# Altium schematic sheet ESP32S3_2511.SchDoc
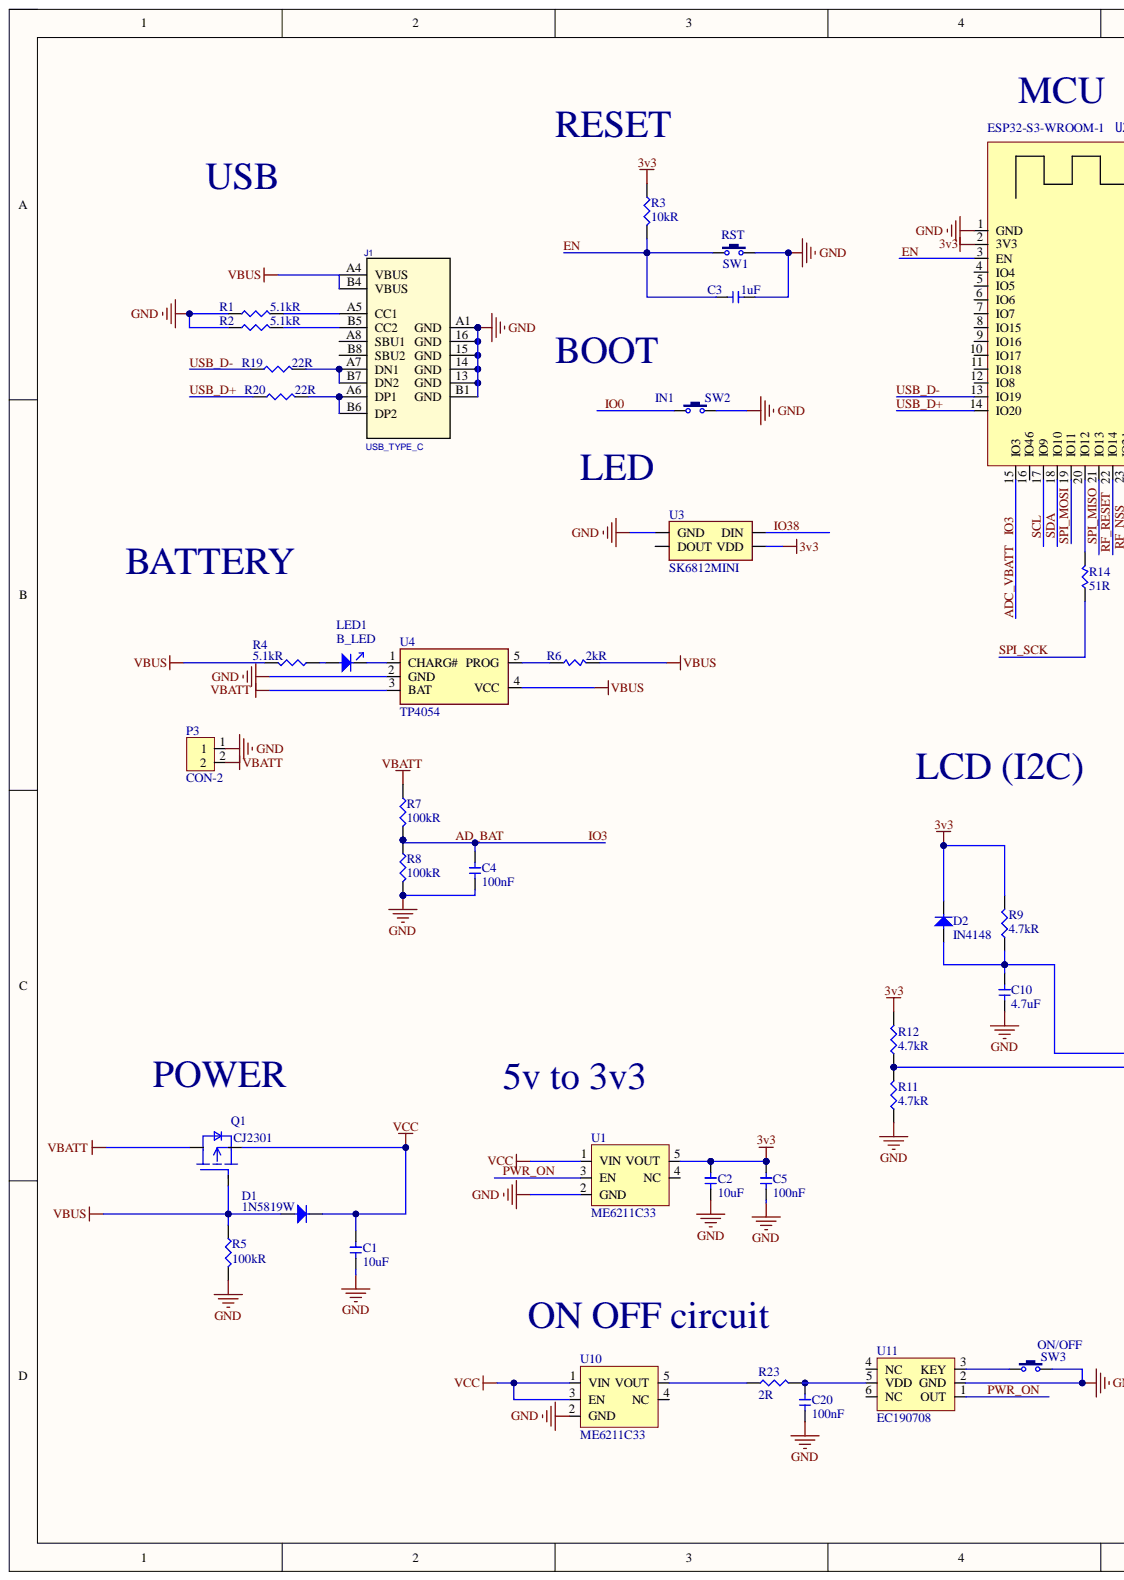
[diagram p0: ESP32S3_2511.SchDoc, left]
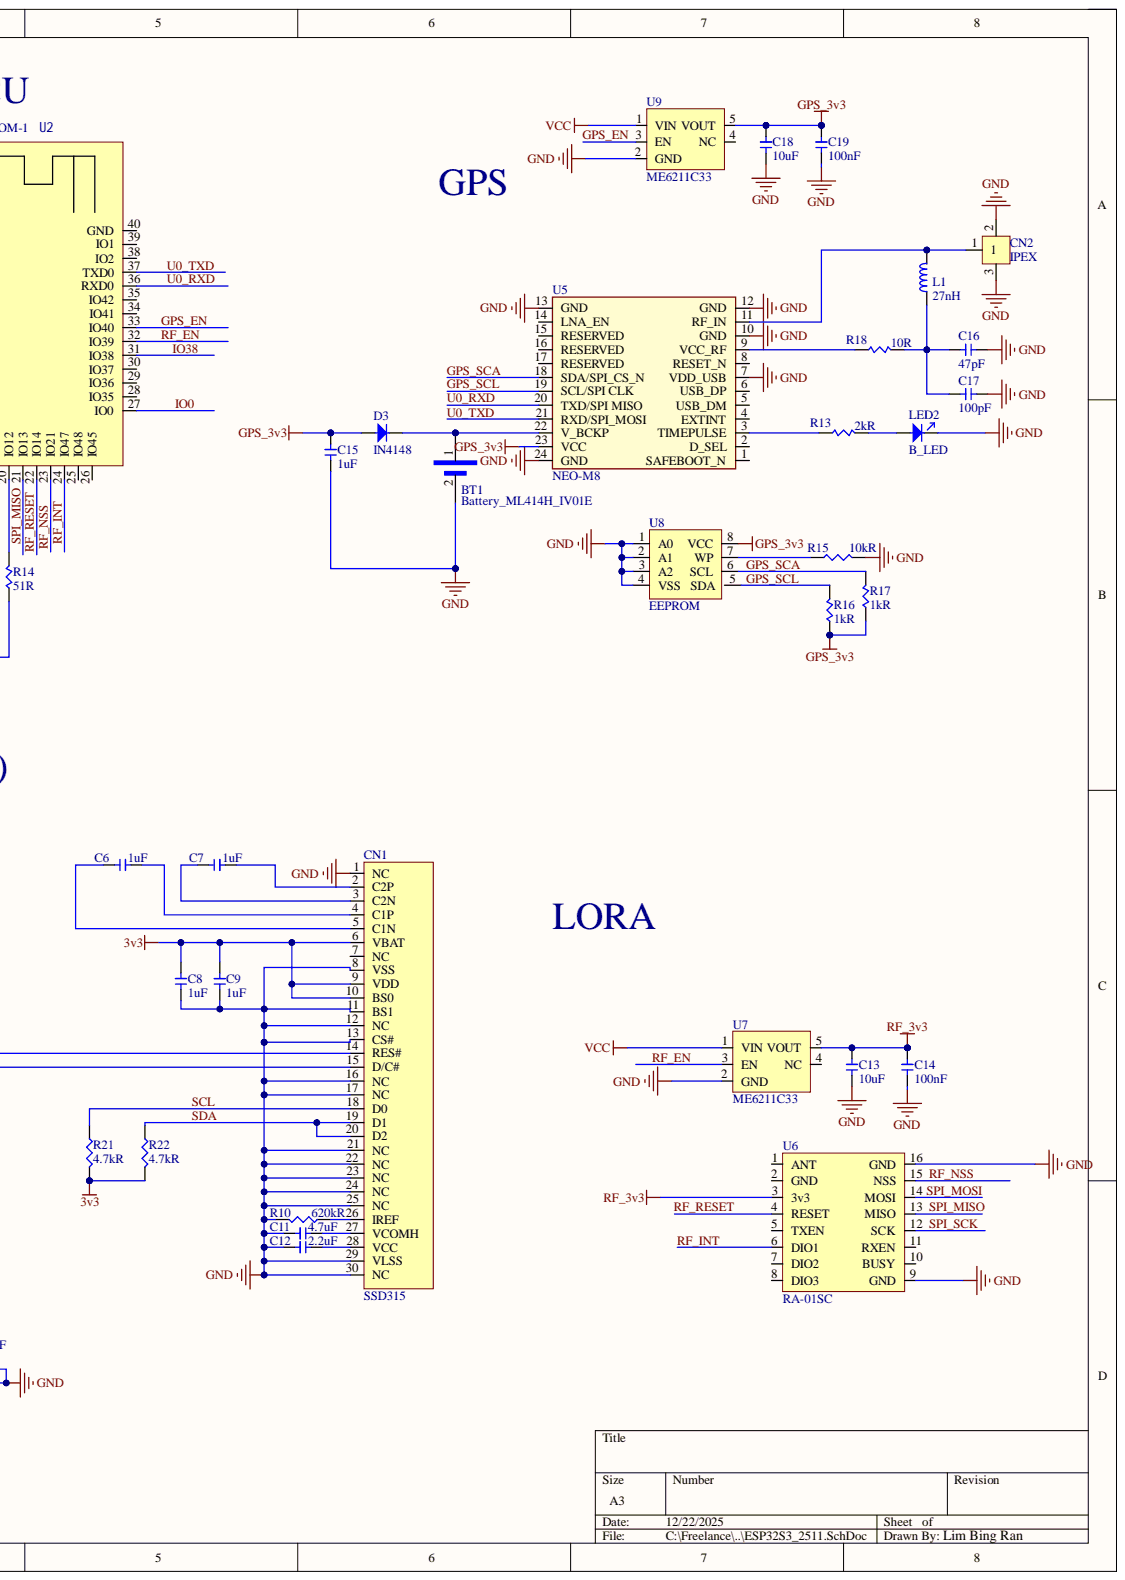
[diagram p1: ESP32S3_2511.SchDoc, right]

## Parts
BT1  Battery_ML414H_IV01E  [SEIKO_ML414H_IV01E]
C1  10uF  [0603C]
C2  10uF  [0603C]
C3  1uF  [0603C]
C4  100nF  [0603C]
C5  100nF  [0603C]
C6  1uF  [0603C]
C7  1uF  [0603C]
C8  1uF  [0603C]
C9  1uF  [0603C]
C10  4.7uF  [0603C]
C11  4.7uF  [0603C]
C12  2.2uF  [0603C]
C13  10uF  [0603C]
C14  100nF  [0603C]
C15  1uF  [0603C]
C16  47pF  [0603C]
C17  100pF  [0603C]
C18  10uF  [0603C]
C19  100nF  [0603C]
C20  100nF  [0603C]
CN1  SSD315  [FPC 30P 0.5mm TOP CNT SMD]
CN2  IPEX  [IPEX_20279-001E-03]
D1  1N5819W  [SOD-123]
D2  IN4148  [SOD-123]
D3  IN4148  [SOD-123]
J1  USB_TYPE_C  [USB16-typeC_8.94x6.2mm]
L1  27nH  [0603L]
LED1  B_LED  [led0603 - blue]
LED2  B_LED  [led0603 - blue]
P3  CON-2  [JST_S2B-PH-K-S(LF)(SN)]
Q1  CJ2301  [sot23-3]
R1  5.1kR  [0603]
R2  5.1kR  [0603]
R3  10kR  [0603]
R4  5.1kR  [0603]
R5  100kR  [0603]
R6  2kR  [0603]
R7  100kR  [0603]
R8  100kR  [0603]
R9  4.7kR  [0603]
R10  620kR  [0603]
R11  4.7kR  [0603]
R12  4.7kR  [0603]
R13  2kR  [0603]
R14  51R  [0603]
R15  10kR  [0603]
R16  1kR  [0603]
R17  1kR  [0603]
R18  10R  [0603]
R19  22R  [0603]
R20  22R  [0603]
R21  4.7kR  [0603]
R22  4.7kR  [0603]
R23  2R  [0603]
SW1  RST  [KEY 3.2*4.2*2.5 4P SMD]
SW2  IN1  [KEY 3.2*4.2*2.5 4P SMD]
SW3  ON/OFF  [TACSW SMD 6MMX6MM - 3D]
U1  ME6211C33  [SOT-23-5]
U2  ESP32-S3-WROOM-1  [ESP32-S3-WROOM]
U3  SK6812MINI  [SK6812MINI]
U4  TP4054  [SOT-23-5]
U5  NEO-M8  [NEO]
U6  RA-01SC  [Ra-01SC-P]
U7  ME6211C33  [SOT-23-5]
U8  EEPROM  [SOIC-8]
U9  ME6211C33  [SOT-23-5]
U10  ME6211C33  [SOT-23-5]
U11  EC190708  [SOT-23-6]

## Nets
3v3: C2.1 C5.1 C8.1 C9.1 CN1.6(VBAT) CN1.9(VDD) CN1.10(BS0) D2.K R3.1 R9.2 R12.2 R21.2 R22.2 U1.5(VOUT) U2.2(3V3) U3.VDD
GND: BT1.2 C1.2 C2.2 C3.1 C4.2 C5.2 C8.2 C9.2 C10.2 C11.1 C12.1 C13.2 C14.2 C15.2 C16.2 C17.2 C18.2 C19.2 C20.2 CN1.1(NC) CN1.8(VSS) CN1.11(BS1) CN1.12(NC) CN1.13(CS#) CN1.16(NC) CN1.17(NC) CN1.21(NC) CN1.22(NC) CN1.23(NC) CN1.24(NC) CN1.25(NC) CN1.29(VLSS) CN1.30(NC) CN2.2 CN2.3 J1.13(GND) J1.14(GND) J1.15(GND) J1.16(GND) J1.A1(GND) J1.B1(GND) LED2.2 P3.1 R1.1 R2.1 R5.2 R8.2 R10.2 R11.1 R15.2 SW1.2 SW2.2 SW3.2 U1.2(GND) U2.1(GND) U3.VSS(GND) U4.2(GND) U5.7(VDD_USB) U5.10(GND) U5.12(GND) U5.13(GND) U5.24(GND) U6.9(GND) U6.16(GND) U7.2(GND) U8.1(A0) U8.2(A1) U8.3(A2) U8.4(VSS) U9.2(GND) U10.2(GND) U11.2(GND)
GPS_3v3: C15.1 C18.1 C19.1 D3.A R16.1 R17.1 U5.23(VCC) U8.8(VCC) U9.5(VOUT)
RF_3v3: C13.1 C14.1 U6.3(3v3) U7.5(VOUT)
VBATT: P3.2 Q1.3 R7.1 U4.3(BAT)
VBUS: D1.A J1.A4(VBUS) J1.B4(VBUS) Q1.1 R4.2 R5.1 R6.2 U4.4(VCC)
VCC: C1.1 D1.K Q1.2 U1.1(VIN) U7.1(VIN) U9.1(VIN) U10.1(VIN) U10.3(EN)
AD_BAT: C4.1 R7.2 R8.1 U2.15(IO3)
EN: C3.2 R3.2 SW1.1 U2.3(EN)
GPS_EN: U2.33(IO40) U9.3(EN)
GPS_SCA: R17.2 U5.18(SDA/SPI_CS_N) U8.6(SCL)
GPS_SCL: R16.2 U5.19(SCL/SPI_CLK) U8.5(SDA)
IO0: SW2.1 U2.27(IO0)
IO38: U2.31(IO38) U3.DIN
PWR_ON: U1.3(EN) U11.1(OUT)
RF_EN: U2.32(IO39) U7.3(EN)
RF_INT: U2.24(IO47) U6.6(DIO1)
RF_NSS: U2.23(IO21) U6.15(NSS)
RF_RESET: U2.22(IO14) U6.4(RESET)
SCL: CN1.18(D0) R21.1 U2.17(IO9)
SDA: CN1.19(D1) CN1.20(D2) R22.1 U2.18(IO10)
SPI_MISO: U2.21(IO13) U6.13(MISO)
SPI_MOSI: U2.19(IO11) U6.14(MOSI)
SPI_SCK: R14.1 U6.12(SCK)
U0_RXD: U2.36(RXD0) U5.20(TXD/SPI_MISO)
U0_TXD: U2.37(TXD0) U5.21(RXD/SPI_MOSI)
USB_D+: R20.1 U2.14(IO20)
USB_D-: R19.1 U2.13(IO19)
NetBT1_1: BT1.1 D3.K U5.22(V_BCKP)
NetC6_1: C6.1 CN1.4(C1P)
NetC6_2: C6.2 CN1.5(C1N)
NetC7_1: C7.1 CN1.2(C2P)
NetC7_2: C7.2 CN1.3(C2N)
NetC10_1: C10.1 CN1.14(RES#) D2.A R9.1
NetC11_2: C11.2 CN1.27(VCOMH)
NetC12_2: C12.2 CN1.28(VCC)
NetC16_1: C16.1 C17.1 L1.1 R18.2
NetC20_1: C20.1 R23.2 U11.5(VDD)
NetCN1_15: CN1.15(D/C#) R11.2 R12.1
NetCN1_26: CN1.26(IREF) R10.1
NetCN2_1: CN2.1 L1.2 U5.11(RF_IN)
NetJ1_A5: J1.A5(CC1) R1.2
NetJ1_A6: J1.A6(DP1) J1.B6(DP2) R20.2
NetJ1_A7: J1.A7(DN1) J1.B7(DN2) R19.2
NetJ1_B5: J1.B5(CC2) R2.2
NetLED1_1: LED1.1 R4.1
NetLED1_2: LED1.2 U4.1(CHARG#)
NetLED2_1: LED2.1 R13.2
NetR6_1: R6.1 U4.5(PROG)
NetR13_1: R13.1 U5.3(TIMEPULSE)
NetR14_2: R14.2 U2.20(IO12)
NetR15_1: R15.1 U8.7(WP)
NetR18_1: R18.1 U5.9(VCC_RF)
NetR23_1: R23.1 U10.5(VOUT)
NetSW3_1: SW3.1 U11.3(KEY)

Not connected: CN1 7(NC); J1 A8(SBU1) B8(SBU2); U1 4(NC); U2 4(IO4) 5(IO5) 6(IO6) 7(IO7) 8(IO15) 9(IO16) 10(IO17) 11(IO18) 12(IO8) 16(IO46) 25(IO48) 26(IO45) 28(IO35) 29(IO36) 30(IO37) 34(IO41) 35(IO42) 38(IO2) 39(IO1) 40(GND); U3 DOUT; U5 1(SAFEBOOT_N) 2(D_SEL) 4(EXTINT) 5(USB_DM) 6(USB_DP) 8(RESET_N) 14(LNA_EN) 15(RESERVED) 16(RESERVED) 17(RESERVED); U6 1(ANT) 2(GND) 5(TXEN) 7(DIO2) 8(DIO3) 10(BUSY) 11(RXEN); U7 4(NC); U9 4(NC); U10 4(NC); U11 4(NC) 6(NC)

## Library data of the parts
BT1 = Battery_ML414H_IV01E: Coin, 4.8mm 3 V Lithium Battery Rechargeable (Secondary) 1mAh; Manufacturer: Seiko Instruments; PartNumber: ML414H IV01E; Component Kind: Standard; Library Name: BingRan_2019.SchLib
C1 C2 C13 C18 = CAP: 贴片电容（MLCC） 0603 10µF ±20% 10V X5R; Manufacturer: Yageo(国巨); PartNumber: CC0603MRX5R6BB106; Component Kind: Standard; Library Name: BingRan_2019.SchLib
C3 C6-C9 C15 = CAP: 贴片电容（MLCC） 0603 1µF ±10% 25V X5R; Manufacturer: FH(风华); PartNumber: 0603X105K250NT; Component Kind: Standard; Library Name: BingRan_2019.SchLib
C4 C5 C14 C19 C20 = CAP: 贴片电容（MLCC） 0603 100nF ±5% 25V X7R; Manufacturer: FH(风华); PartNumber: 0603B104J250NT; Component Kind: Standard; Library Name: BingRan_2019.SchLib
C10 C11 = CAP: 贴片电容（MLCC） 0603 4.7µF ±20% 10V X5R; Manufacturer: FH(风华); PartNumber: 0603X475M100NT; Component Kind: Standard; Library Name: BingRan_2019.SchLib
C12 = CAP: 贴片电容（MLCC） 0603 2.2µF ±20% 10V X5R; Manufacturer: FH(风华); PartNumber: 0603X225M100NT; Component Kind: Standard; Library Name: BingRan_2019.SchLib
C16 = CAP: 贴片电容（MLCC） 0603 47pF ±5% 25V C0G(NP0); Manufacturer: Yageo(国巨); PartNumber: CC0603JRNPO8BN470; Component Kind: Standard; Library Name: BingRan_2019.SchLib
C17 = CAP: 贴片电容（MLCC） 0603 100pF ±5% 50V C0G(NP0); Manufacturer: Yageo(国巨); PartNumber: CC0603JRNPO9BN101; Component Kind: Standard; Library Name: BingRan_2019.SchLib
CN1 = SSD315: SMD,P=0.5mm,Surface Mount,Right Angle; Manufacturer: SHOU HAN; PartNumber: 0.5-30P XJH2.0 043; Component Kind: Standard; Library Name: BingRan_2019.SchLib
CN2 = IPEX: 射频和同轴连接器 立贴 天线连接座 镀金 内针; Manufacturer: XUNPU; PartNumber: IPEX-001; Component Kind: Standard; Library Name: BingRan_2019.SchLib
D1 = DIODE: 直流反向耐压(Vr)：40V 平均整流电流(Io)：1A 正向压降(Vf)：900mV@3A 反向电流(Ir)：1mA@40V; Manufacturer: Hottech(合科泰); Component Kind: Standard; PartNumber: 1N5819W; Library Name: BingRan_2019.SchLib
D2 D3 = DIODE: 4A 8ns 100V Independent 1.25V@150mA 300mA SOD-123 Single Diodes RoHS; Manufacturer: JSMSEMI; PartNumber: 1N4148W; Component Kind: Standard; Library Name: BingRan_2019.SchLib
J1 = USB_TYPE_C: Manufacturer: BBJconn; PartNumber: UC.01.51-11-0017; Component Kind: Standard; Library Name: BingRan_2019.SchLib
L1 = INDUCTOR: 高频电感 27nH ±5% 540mΩ 500mA 0.80mm 1.60 x 0.80mm 0603; Manufacturer: Murata; PartNumber: LQG18HN27NJ00D; Component Kind: Standard; Library Name: BingRan_2019.SchLib
LED1 LED2 = LED: LED NATIONSTAR 0603 蓝色FC-D1608BK-470H10; Component Kind: Standard; Manufacturer: LED NATIONSTAR; PartNumber: FC-D1608BK-470H10; Library Name: BingRan_2019.SchLib
P3 = CON-2: JST_S2B-PH-K-S(LF)(SN); Manufacturer: JST; Component Kind: Standard; PartNumber: S2B-PH-K-S(LF)(SN); Library Name: BingRan_2019.SchLib
Q1 = P_MOS: 20V 2.3A 350mW 142mΩ@2.5V,2A 1 P-Channel SOT-23 Single FETs, MOSFETs RoHS; Manufacturer: JSCJ; PartNumber: CJ2301 S1; Component Kind: Standard; Library Name: BingRan_2019.SchLib
R1 R2 R4 R9-R12 R21 R22 = RES-0603: 贴片电阻 0603 5.1KΩ ±1% 1/10W ±100ppm/℃; Manufacturer: Yageo(国巨); PartNumber: RC0603FR-075K1L; Component Kind: Standard; Library Name: BingRan_2019.SchLib
R3 R15 = RES-0603: 贴片电阻 0603 10KΩ ±5% 1/10W ±100ppm/℃; Manufacturer: Yageo(国巨); PartNumber: RC0603JR-0710KL; Component Kind: Standard; Library Name: BingRan_2019.SchLib
R5 R7 R8 = RES-0603: 贴片电阻 0603 100KΩ ±1% 1/10W ±100ppm/℃; Manufacturer: Yageo(国巨); PartNumber: RC0603FR-07100KL; Component Kind: Standard; Library Name: BingRan_2019.SchLib
R6 R13 = RES: 贴片电阻 0603 2KΩ ±0.5% 1/10W ±25ppm/℃; Manufacturer: Yageo(国巨); PartNumber: RT0603DRD072KL; Component Kind: Standard; Library Name: BingRan_2019.SchLib
R14 = RES: 贴片电阻 0603 51Ω ±1% 1/10W ±100ppm/℃; Manufacturer: Yageo(国巨); PartNumber: RC0603FR-0751RL; Component Kind: Standard; Library Name: BingRan_2019.SchLib
R16 R17 = RES: 贴片电阻 0603 1KΩ ±5% 1/10W ±100ppm/℃; Manufacturer: Yageo(国巨); PartNumber: RC0603JR-071KL; Component Kind: Standard; Library Name: BingRan_2019.SchLib
R18 = RES: 贴片电阻 0603 10Ω ±5% 1/10W ±200ppm/℃; Manufacturer: Yageo(国巨); PartNumber: RC0603JR-0710RL; Component Kind: Standard; Library Name: BingRan_2019.SchLib
R19 R20 = RES-0603: 贴片电阻 0603 22Ω ±5% 1/10W ±100ppm/℃; Manufacturer: Yageo(国巨); PartNumber: RC0603JR-0722RL; Component Kind: Standard; Library Name: BingRan_2019.SchLib
R23 = RES-0603: 贴片电阻 0603 2Ω ±1% 1/10W ±200ppm/℃; Manufacturer: Yageo(国巨); PartNumber: RC0603FR-072RL; Component Kind: Standard; Library Name: BingRan_2019.SchLib
SW1 SW2 = SW 3x6: 轻触开关 3x6x2.5 立贴 250克力 寿命5万次 50mA 12VDC 白头; Manufacturer: BRIGHT(博瑞泰); PartNumber: TSA363G25-250B; Component Kind: Standard; Library Name: BingRan_2019.SchLib
SW3 = SW 3x6: 轻触开关 SMD-4P,6x6mm SPDT 80000 Cycles 12V 50mA; Manufacturer: Kinghelm(金航标); PartNumber: KH-6X6X5H-STM; Component Kind: Standard; Library Name: BingRan_2019.SchLib
U1 U10 = ME6211C33M5G-N: 线性稳压器/LDO 3.3V 500mA SOT23-5 70dB -40℃~+150℃; Parameter 2: MICRONE(南京微盟); Component Kind: Standard; Parameter 1: ME6211C33M5G-N; Library Name: BingRan_2019.SchLib
U2 = ESP32-S3-WROOM-1: ESP32-S3-WROOM-1-N16R8 Wi-Fi+蓝牙5.0 16MB32位双核; PartNumber: espressif; Component Kind: Standard; Manufacturer: ESP32-S3-WROOM-1-N16R8; Library Name: BingRan_2019.SchLib
U3 = SK6812MINI: INTEGRATED LIGHT SOURCE INTELLIGENT CONTROL OF CHIP-ON-TOP SMD TYPE LED; Manufacturer: DONGGUANG OPSCO OPTOELECTRONICS CO., LTD; Component Kind: Standard; PartNumber: SK6812MINI; Library Name: BingRan_2019.SchLib
U4 = TP4054: 电池充电管理 SOT23-5 500mA 4.2V -40℃~+85℃; Manufacturer: UMW(友台); Component Kind: Standard; PartNumber: TP4054; Library Name: BingRan_2019.SchLib
U5 = NEO M8N: u-blox NEO GNSS module; Part Description: u-blox GNSS module; Component Kind: Standard; Manufacturer: u-blox; Value: NEO-M8; Library Name: BingRan_2019.SchLib
U6 = RA-01SC: IPEX Connector -129dBm 2.8km SPI SMD,17x16mm RF Transceiver Modules and Modems RoHS; Manufacturer: Ai-Thinker; Component Kind: Standard; PartNumber: Ra-01sC-P; Library Name: BingRan_2019.SchLib
U7 U9 = ME6211C33M5G-N: 线性稳压器/LDO 3.3V 500mA SOT23-5 70dB -40℃~+150℃; Manufacturer: MICRONE(南京微盟); Component Kind: Standard; PartNumber: ME6211C33M5G-N; Library Name: BingRan_2019.SchLib
U8 = EEPROM: Manufacturer: CAT24C256WI-GT3; PartNumber: ONSEMI; Component Kind: Standard; Library Name: BingRan_2019.SchLib
U11 = EC190708: 触摸模拟按键IC 2.2V~5V 1.5mA SOT23-6; Manufacturer: ELITECHIP; Parameter: EC190708-3-29CE-EBD7; Component Kind: Standard; Library Name: BingRan_2019.SchLib
